# Altium schematic sheet Base_Circuit_REV04.SchDoc
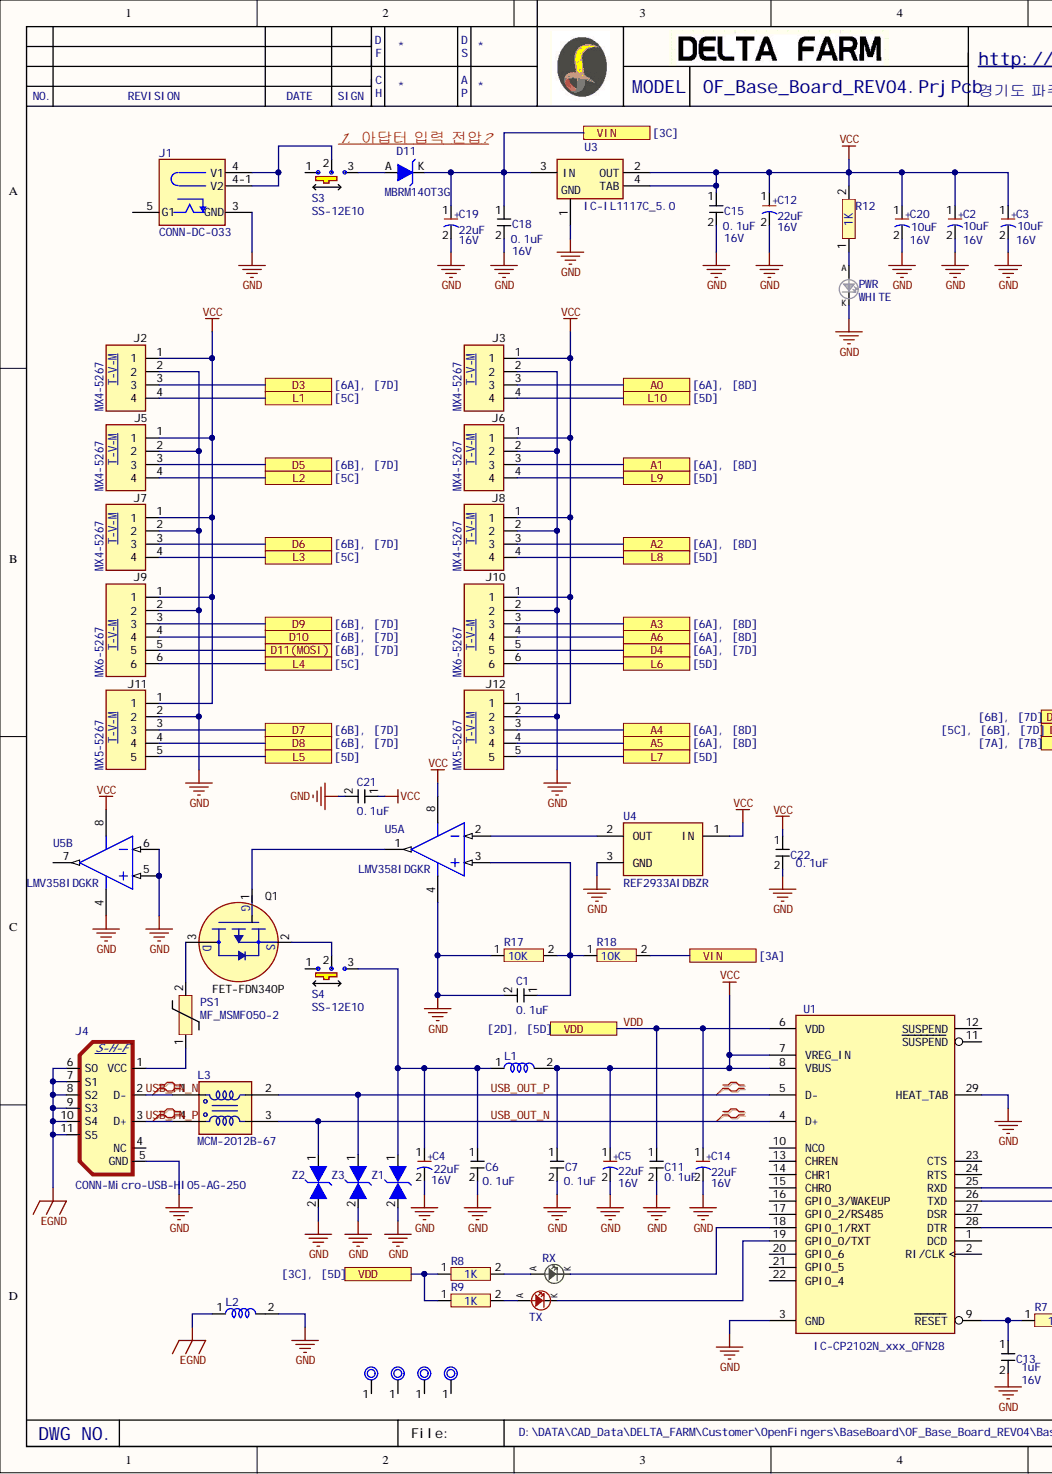
[diagram p0: Base_Circuit_REV04.SchDoc, left]
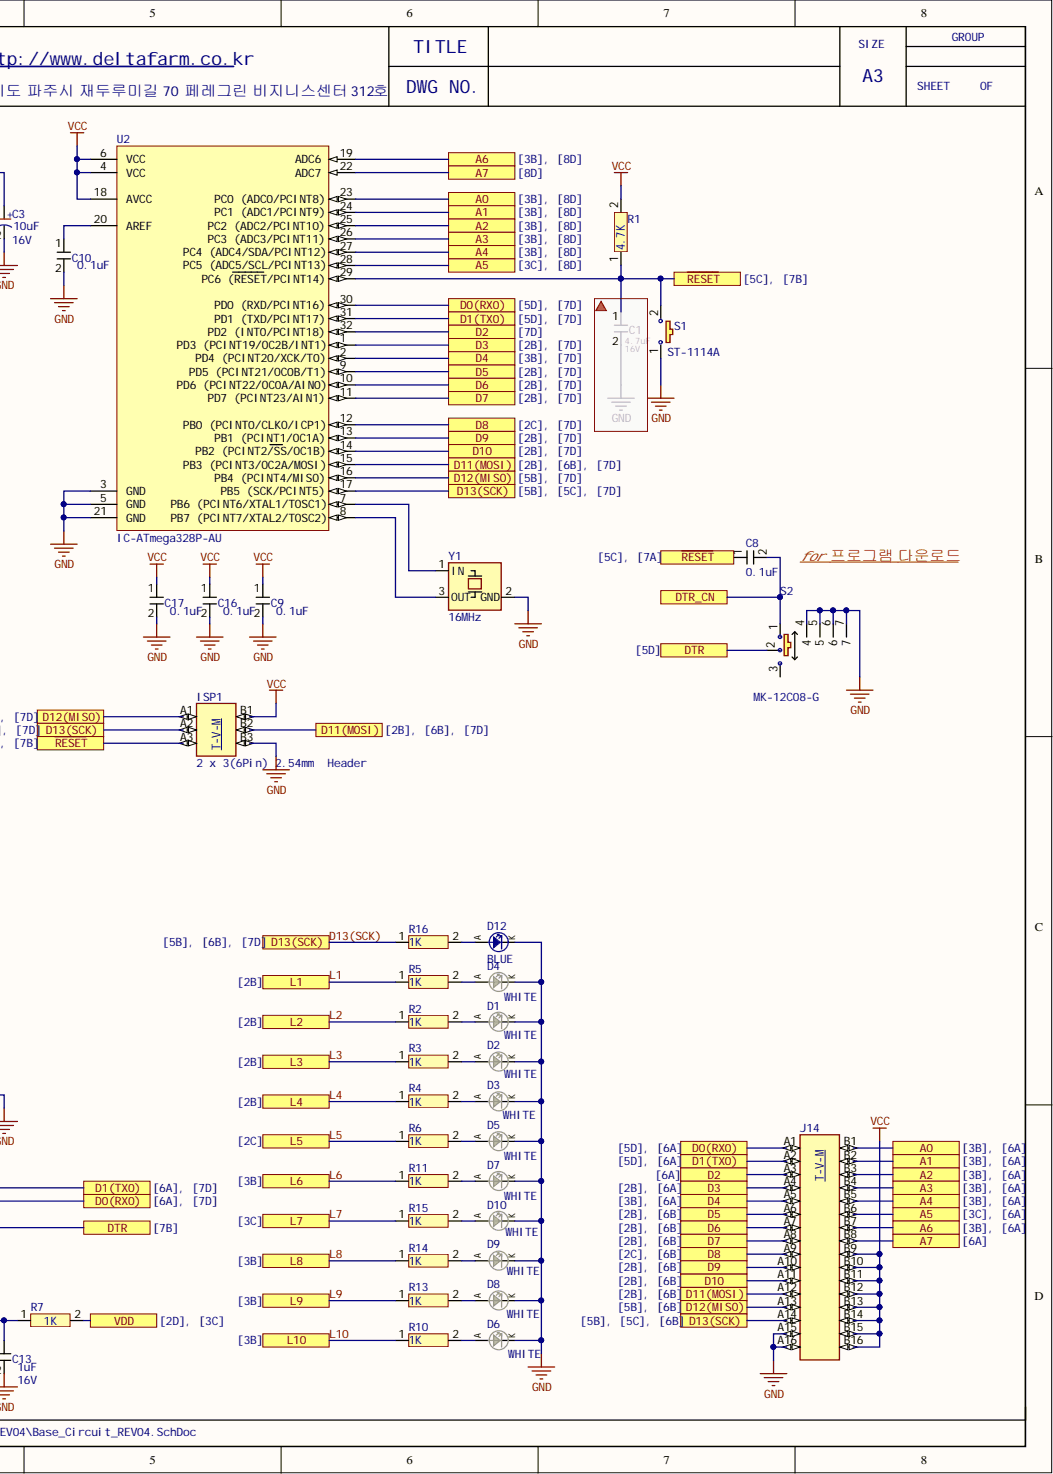
[diagram p1: Base_Circuit_REV04.SchDoc, right]

## Parts
C1  value 0.1uF  [CAP1608(EIA-0603)]  (hidden comment: CAP1608)
C2  value 10uF  VOLTAGE: 16V  [CAP-AE-B(D55)]  (hidden comment: CAP-AE-B(D55))
C3  value 10uF  VOLTAGE: 16V  [CAP-AE-B(D55)]  (hidden comment: CAP-AE-B(D55))
C4  value 22uF  VOLTAGE: 16V  [CAP-AE-C(E55)]  (hidden comment: CAP-AE-C(E55))
C5  value 22uF  VOLTAGE: 16V  [CAP-AE-C(E55)]  (hidden comment: CAP-AE-C(E55))
C6  value 0.1uF  [CAP1608(EIA-0603)]  (hidden comment: CAP1608)
C7  value 0.1uF  [CAP1608(EIA-0603)]  (hidden comment: CAP1608)
C8  value 0.1uF  [CAP1608(EIA-0603)]  (hidden comment: CAP1608)
C9  value 0.1uF  [CAP1608(EIA-0603)]  (hidden comment: CAP1608)
C10  value 0.1uF  [CAP1608(EIA-0603)]  (hidden comment: CAP1608)
C11  value 0.1uF  [CAP1608(EIA-0603)]  (hidden comment: CAP1608)
C12  value 22uF  VOLTAGE: 16V  [CAP-AE-C(E55)]  (hidden comment: CAP-AE-C(E55))
C13  value 1uF  VOLTAGE: 16V  [CAP1608(EIA-0603)]  (hidden comment: CAP1608)
C14  value 22uF  VOLTAGE: 16V  [CAP-AE-C(E55)]  (hidden comment: CAP-AE-C(E55))
C15  value 0.1uF  VOLTAGE: 16V  [CAP1608(EIA-0603)]  (hidden comment: CAP1608)
C16  value 0.1uF  [CAP1608(EIA-0603)]  (hidden comment: CAP1608)
C17  value 0.1uF  [CAP1608(EIA-0603)]  (hidden comment: CAP1608)
C18  value 0.1uF  VOLTAGE: 16V  [CAP1608(EIA-0603)]  (hidden comment: CAP1608)
C19  value 22uF  VOLTAGE: 16V  [CAP-AE-C(E55)]  (hidden comment: CAP-AE-C(E55))
C20  value 10uF  VOLTAGE: 16V  [CAP-AE-B(D55)]  (hidden comment: CAP-AE-B(D55))
C21  value 0.1uF  [CAP1608(EIA-0603)]  (hidden comment: CAP1608)
C22  value 0.1uF  [CAP1608(EIA-0603)]  (hidden comment: CAP1608)
D1  COLOR: WHITE  [LED3528(EIA-12108)_WHT]  (hidden comment: LED3528(EIA-12108)_WHT)
D2  COLOR: WHITE  [LED3528(EIA-12108)_WHT]  (hidden comment: LED3528(EIA-12108)_WHT)
D3  COLOR: WHITE  [LED3528(EIA-12108)_WHT]  (hidden comment: LED3528(EIA-12108)_WHT)
D4  COLOR: WHITE  [LED3528(EIA-12108)_WHT]  (hidden comment: LED3528(EIA-12108)_WHT)
D5  COLOR: WHITE  [LED3528(EIA-12108)_WHT]  (hidden comment: LED3528(EIA-12108)_WHT)
D6  COLOR: WHITE  [LED3528(EIA-12108)_WHT]  (hidden comment: LED3528(EIA-12108)_WHT)
D7  COLOR: WHITE  [LED3528(EIA-12108)_WHT]  (hidden comment: LED3528(EIA-12108)_WHT)
D8  COLOR: WHITE  [LED3528(EIA-12108)_WHT]  (hidden comment: LED3528(EIA-12108)_WHT)
D9  COLOR: WHITE  [LED3528(EIA-12108)_WHT]  (hidden comment: LED3528(EIA-12108)_WHT)
D10  COLOR: WHITE  [LED3528(EIA-12108)_WHT]  (hidden comment: LED3528(EIA-12108)_WHT)
D11  PART NO.: MBRM140T3G  [CASE457-04]  (hidden comment: DIODE-Schottky Power-MBRM140T3G)
D12  COLOR: BLUE  [LED1608(EIA-0603)-BLUE]  (hidden comment: LED1608(EIA-0603)_BLUE)
ISP1  2 x 3(6Pin) 2.54mm  Header  [CONN-SAMTEC_TSW-103-07-G-D]
J1  CONN-DC-033  [CONN-DC-JACK-DC033]
J2  PART NO: MX4-5267  [CONN-MX4-5267]  (hidden comment: CONN-MX4-5267)
J3  PART NO: MX4-5267  [CONN-MX4-5267]  (hidden comment: CONN-MX4-5267)
J4  CONN-Micro-USB-HI05-AG-250  [CONN-Micro-USB-HI05-AG-250]
J5  PART NO: MX4-5267  [CONN-MX4-5267]  (hidden comment: CONN-MX4-5267)
J6  PART NO: MX4-5267  [CONN-MX4-5267]  (hidden comment: CONN-MX4-5267)
J7  PART NO: MX4-5267  [CONN-MX4-5267]  (hidden comment: CONN-MX4-5267)
J8  PART NO: MX4-5267  [CONN-MX4-5267]  (hidden comment: CONN-MX4-5267)
J9  PART NO: MX6-5267  [CONN-MX6-5267]  (hidden comment: CONN-MX6-5267)
J10  PART NO: MX6-5267  [CONN-MX6-5267]  (hidden comment: CONN-MX6-5267)
J11  PART NO: MX5-5267  [CONN-MX5-5267]  (hidden comment: CONN-MX5-5267)
J12  PART NO: MX5-5267  [CONN-MX5-5267]  (hidden comment: CONN-MX5-5267)
J14  2 x 16(32Pin) 2.54mm Pitch Stacker  [CONN-SAMTEC_TSW-116-07-G-D]  (value not drawn)
L1  IND-FCM1608KF-221T05  [DUMMY_1608(EIA-0603)]  (value not drawn)
L2  IND-FCM1608KF-221T05  [DUMMY_1608(EIA-0603)]  (value not drawn)
L3  PART NO.: MCM-2012B-67  [IND-2012 (EIAJ2012 X 2)]  (hidden comment: Common_Mode Choke MCM 2012 B Series)
N1  DRIL_3.2_PAD_6.0  [MT_HOLE_3.2_6]  (value not drawn)
N2  DRIL_3.2_PAD_6.0  [MT_HOLE_3.2_6]  (value not drawn)
N3  DRIL_3.2_PAD_6.0  [MT_HOLE_3.2_6]  (value not drawn)
N4  DRIL_3.2_PAD_6.0  [MT_HOLE_3.2_6]  (value not drawn)
PS1  PART NO.: MF_MSMF050-2  [POLY4532(EIA-1812)]  (hidden comment: POLY_MF_MSMF050-2)
PWR  COLOR: WHITE  [LED3528(EIA-12108)_WHT]  (hidden comment: LED3528(EIA-12108)_WHT)
Q1  FET-FDN340P  [SOT23 -GSD]
R1  value 4.7K  [RES1608(EIA-0603)]  (hidden comment: RES1608(EIA-0603))
R2  value 1K  [RES1608(EIA-0603)]  (hidden comment: RES1608(EIA-0603))
R3  value 1K  [RES1608(EIA-0603)]  (hidden comment: RES1608(EIA-0603))
R4  value 1K  [RES1608(EIA-0603)]  (hidden comment: RES1608(EIA-0603))
R5  value 1K  [RES1608(EIA-0603)]  (hidden comment: RES1608(EIA-0603))
R6  value 1K  [RES1608(EIA-0603)]  (hidden comment: RES1608(EIA-0603))
R7  value 1K  [RES1608(EIA-0603)]  (hidden comment: RES1608(EIA-0603))
R8  value 1K  [RES1608(EIA-0603)]  (hidden comment: RES1608(EIA-0603))
R9  value 1K  [RES1608(EIA-0603)]  (hidden comment: RES1608(EIA-0603))
R10  value 1K  [RES1608(EIA-0603)]  (hidden comment: RES1608(EIA-0603))
R11  value 1K  [RES1608(EIA-0603)]  (hidden comment: RES1608(EIA-0603))
R12  value 1K  [RES1608(EIA-0603)]  (hidden comment: RES1608(EIA-0603))
R13  value 1K  [RES1608(EIA-0603)]  (hidden comment: RES1608(EIA-0603))
R14  value 1K  [RES1608(EIA-0603)]  (hidden comment: RES1608(EIA-0603))
R15  value 1K  [RES1608(EIA-0603)]  (hidden comment: RES1608(EIA-0603))
R16  value 1K  [RES1608(EIA-0603)]  (hidden comment: RES1608(EIA-0603))
R17  value 10K  [RES1608(EIA-0603)]  (hidden comment: RES1608(EIA-0603))
R18  value 10K  [RES1608(EIA-0603)]  (hidden comment: RES1608(EIA-0603))
RX  LED1608(EIA-0603)_GRN  [LED1608(EIA-0603)-GREEN]  (value not drawn)
S1  PART NO.: ST-1114A  [SW-TACT- ST-1114A]  (hidden comment: SW-TACT-ST-1114A)
S2  PART NO.: MK-12C08-G  [SW-Slide- MK12C08-G]  (hidden comment: SW-SLIDE-MK-12C08-G)
S3  PART NO.: SS-12E10  [SW-SLIDE-SS-12E10]  (hidden comment: SW-SLIDE-SS-12E10)
S4  PART NO.: SS-12E10  [SW-SLIDE-SS-12E10]  (hidden comment: SW-SLIDE-SS-12E10)
TX  LED1608(EIA-0603)_RED  [LED1608(EIA-0603)-RED]  (value not drawn)
U1  IC-CP2102N_xxx_QFN28  [QFN-28-5X5]
U2  IC-ATmega328P-AU  [TQFP-32-7X7]
U3  IC-IL1117C_5.0  [SOT-223]
U4  REF2933AIDBZR  [SOT23]
U5  LMV358IDGKR  [DGK0008A_L]  (parts A,B)
Y1  value 16MHz  [XTAL-CST  Series]  (hidden comment: CRYSTAL-CSTCE-XXX)
Z1  TVS-TEA10402V  [DUMMY_1005(EIA-0402)]  (value not drawn)
Z2  TVS-TEA10402V  [DUMMY_1005(EIA-0402)]  (value not drawn)
Z3  TVS-TEA10402V  [DUMMY_1005(EIA-0402)]  (value not drawn)

## Ports
A0, A1, A2, A3, A4, A5, A6, A7, D0(RX0), D1(TX0), D2, D3, D4, D5, D6, D7, D8, D9, D10, D11(MOSI), D12(MISO), D13(SCK), DTR, DTR_CN, L1, L2, L3, L4, L5, L6, L7, L8, L9, L10, VDD, VIN, ~{RESET}

## Nets
EGND: J4.6(S0) J4.7(S1) J4.8(S2) J4.9(S3) J4.10(S4) J4.11(S5) L2.1
GND: C1.2 C2.2 C3.2 C4.2 C5.2 C6.2 C7.2 C9.2 C10.2 C11.2 C12.2 C13.2 C14.2 C15.2 C16.2 C17.2 C18.2 C19.2 C20.2 C21.2 C22.2 D1.K D2.K D3.K D4.K D5.K D6.K D7.K D8.K D9.K D10.K D12.K ISP1.B3 J1.3(GND) J2.2 J3.2 J4.5(GND) J5.2 J6.2 J7.2 J8.2 J9.2 J10.2 J11.2 J12.2 J14.A15 J14.A16 L2.2 PWR.K R17.1 S1.1 S2.4 S2.5 S2.6 S2.7 U1.3(GND) U1.29(HEAT_TAB) U2.3(GND) U2.5(GND) U2.21(GND) U3.1 U4.3 U5.4 U5.5 U5.6 Y1.2 Z1.2 Z2.2 Z3.2
VCC: C2.1 C3.1 C5.1 C7.1 C9.1 C12.1 C15.1 C16.1 C17.1 C20.1 C21.1 C22.1 ISP1.B1 J2.1 J3.1 J5.1 J6.1 J7.1 J8.1 J9.1 J10.1 J11.1 J12.1 J14.B9 J14.B10 J14.B11 J14.B12 J14.B13 J14.B14 J14.B15 J14.B16 L1.2 R1.2 R12.2 U1.7(VREG_IN) U1.8(VBUS) U2.4(VCC) U2.6(VCC) U2.18(AVCC) U3.2(OUT) U3.4(TAB) U4.1 U5.8
A0: J3.3 J14.B1 U2.23((ADC0/PCINT8)) ; port A0
A1: J6.3 J14.B2 U2.24((ADC1/PCINT9)) ; port A1
A2: J8.3 J14.B3 U2.25((ADC2/PCINT10)) ; port A2
A3: J10.3 J14.B4 U2.26((ADC3/PCINT11)) ; port A3
A4: J12.3 J14.B5 U2.27((ADC4/SDA/PCINT12)) ; port A4
A5: J12.4 J14.B6 U2.28((ADC5/SCL/PCINT13)) ; port A5
A6: J10.4 J14.B7 U2.19(ADC6) ; port A6
A7: J14.B8 U2.22(ADC7) ; port A7
D0(RX0): J14.A1 U1.26(TXD) U2.30((RXD/PCINT16)) ; port D0(RX0)
D1(TX0): J14.A2 U1.25(RXD) U2.31((TXD/PCINT17)) ; port D1(TX0)
D2: J14.A3 U2.32((INT0/PCINT18)) ; port D2
D3: J2.3 J14.A4 U2.1((PCINT19/OC2B/INT1)) ; port D3
D4: J10.5 J14.A5 U2.2((PCINT20/XCK/T0)) ; port D4
D5: J5.3 J14.A6 U2.9((PCINT21/OC0B/T1)) ; port D5
D6: J7.3 J14.A7 U2.10((PCINT22/OC0A/AIN0)) ; port D6
D7: J11.3 J14.A8 U2.11((PCINT23/AIN1)) ; port D7
D8: J11.4 J14.A9 U2.12((PCINT0/CLKO/ICP1)) ; port D8
D9: J9.3 J14.A10 U2.13((PCINT1/OC1A)) ; port D9
D10: J9.4 J14.A11 U2.14((PCINT2/SS/OC1B)) ; port D10
D11(MOSI): ISP1.B2 J9.5 J14.A12 U2.15((PCINT3/OC2A/MOSI)) ; port D11(MOSI)
D12(MISO): ISP1.A1 J14.A13 U2.16((PCINT4/MISO)) ; port D12(MISO)
D13(SCK): ISP1.A2 J14.A14 R16.1 U2.17((SCK/PCINT5)) ; port D13(SCK)
DTR: S2.2 U1.28(DTR) ; port DTR
DTR_CN: C8.2 S2.1 ; port DTR_CN
L1: J2.4 R5.1 ; port L1
L2: J5.4 R2.1 ; port L2
L3: J7.4 R3.1 ; port L3
L4: J9.6 R4.1 ; port L4
L5: J11.5 R6.1 ; port L5
L6: J10.6 R11.1 ; port L6
L7: J12.5 R15.1 ; port L7
L8: J8.4 R14.1 ; port L8
L9: J6.4 R13.1 ; port L9
L10: J3.4 R10.1 ; port L10
~{RESET}: C8.1 ISP1.A3 R1.1 S1.2 U2.29((RESET/PCINT14)) ; port ~{RESET}
USB_IN_N: J4.2(D-) L3.1
USB_IN_P: J4.3(D+) L3.4
USB_OUT_N: L3.3 U1.4(D+) Z2.1
USB_OUT_P: L3.2 U1.5(D-) Z3.1
VDD: C11.1 C14.1 R7.2 R8.1 R9.1 U1.6(VDD) ; port VDD
VIN: C18.1 C19.1 D11.K R18.2 U3.3(IN) ; port VIN
NetC1_1: C1.1 R17.2 R18.1 U5.3
NetC4_1: C4.1 C6.1 L1.1 S4.3 Z1.1
NetC10_1: C10.1 U2.20(AREF)
NetC13_1: C13.1 R7.1 U1.9(RESET)
NetD1_A: D1.A R2.2
NetD2_A: D2.A R3.2
NetD3_A: D3.A R4.2
NetD4_A: D4.A R5.2
NetD5_A: D5.A R6.2
NetD6_A: D6.A R10.2
NetD7_A: D7.A R11.2
NetD8_A: D8.A R13.2
NetD9_A: D9.A R14.2
NetD10_A: D10.A R15.2
NetD11_A: D11.A S3.3
NetD12_A: D12.A R16.2
NetJ1_4: J1.4(V1) J1.4-1(V2) S3.2
NetJ4_1: J4.1(VCC) PS1.1
NetPS1_2: PS1.2 Q1.3
NetPWR_A: PWR.A R12.1
NetQ1_1: Q1.1 U5.1
NetQ1_2: Q1.2 S4.2
NetR8_2: R8.2 RX.A
NetR9_2: R9.2 TX.A
NetRX_K: RX.K U1.18(GPIO_1/RXT)
NetTX_K: TX.K U1.19(GPIO_0/TXT)
NetU2_7: U2.7((PCINT6/XTAL1/TOSC1)) Y1.1
NetU2_8: U2.8((PCINT7/XTAL2/TOSC2)) Y1.3
NetU4_2: U4.2 U5.2

Not connected: J1 5(G1); J4 4(NC); N1 1; N2 1; N3 1; N4 1; S2 3; S3 1; S4 1; U1 1(DCD) 2(RI/CLK) 10(NC0) 11(SUSPEND) 12(SUSPEND) 13(CHREN) 14(CHR1) 15(CHR0) 16(GPIO_3/WAKEUP) 17(GPIO_2/RS485) 20(GPIO_6) 21(GPIO_5) 22(GPIO_4) 23(CTS) 24(RTS) 27(DSR); U5 7

## Library data of the parts
C1 C6-C11 C16 C17 C22 = CAP1608(EIA-0603): CAP 1608 ,EIA 0603; VOLTAGE: 16V; Component Kind: Standard; ComponentLink1Description: Manufacturer URL; ComponentLink1URL: http://www.samtec.com; ComponentLink2Description: Datasheet; ComponentLink2URL: http://www.samtec.com/documents/webfiles/pdf/TSW_TH.PDF; ComponentLink3Description: Package Specification; ComponentLink3URL: http://www.samtec.com/documents/webfiles/cpdf/TSW-XXX-XX-XXX-X-XX-XXX-MKT.pdf; Library Name: DeltaFarm_Schlib.SchLib
C2 C3 C20 = CAP-AE-B(D55): Aluminum Electrolytic Capacitor, Size_B(D55); Component Kind: Standard; ComponentLink1Description: Manufacturer URL; ComponentLink1URL: http://www.samtec.com; ComponentLink2Description: Datasheet; ComponentLink2URL: http://www.samtec.com/documents/webfiles/pdf/TSW_TH.PDF; ComponentLink3Description: Package Specification; ComponentLink3URL: http://www.samtec.com/documents/webfiles/cpdf/TSW-XXX-XX-XXX-X-XX-XXX-MKT.pdf; Library Name: DeltaFarm_Schlib.SchLib
C4 C5 C12 C14 C19 = CAP-AE-C(E55): Aluminum Electrolytic Capacitor, Size_C(E55); Component Kind: Standard; ComponentLink1Description: Manufacturer URL; ComponentLink1URL: http://www.samtec.com; ComponentLink2Description: Datasheet; ComponentLink2URL: http://www.samtec.com/documents/webfiles/pdf/TSW_TH.PDF; ComponentLink3Description: Package Specification; ComponentLink3URL: http://www.samtec.com/documents/webfiles/cpdf/TSW-XXX-XX-XXX-X-XX-XXX-MKT.pdf; Library Name: DeltaFarm_Schlib.SchLib
C13 C15 C18 = CAP1608(EIA-0603): CAP 1608 ,EIA 0603; Component Kind: Standard; ComponentLink1Description: Manufacturer URL; ComponentLink1URL: http://www.samtec.com; ComponentLink2Description: Datasheet; ComponentLink2URL: http://www.samtec.com/documents/webfiles/pdf/TSW_TH.PDF; ComponentLink3Description: Package Specification; ComponentLink3URL: http://www.samtec.com/documents/webfiles/cpdf/TSW-XXX-XX-XXX-X-XX-XXX-MKT.pdf; Library Name: DeltaFarm_Schlib.SchLib
C21 = CAP1608(EIA-0603): CAP 1608 ,EIA 0603; VALUE: 0.1uF; VOLTAGE: 16V; Component Kind: Standard; ComponentLink1Description: Manufacturer URL; ComponentLink1URL: http://www.samtec.com; ComponentLink2Description: Datasheet; ComponentLink2URL: http://www.samtec.com/documents/webfiles/pdf/TSW_TH.PDF; ComponentLink3Description: Package Specification; ComponentLink3URL: http://www.samtec.com/documents/webfiles/cpdf/TSW-XXX-XX-XXX-X-XX-XXX-MKT.pdf; Library Name: DeltaFarm_Schlib.SchLib
D1-D10 PWR = LED3528(EIA-1210)_WHT: Component Kind: Standard; ComponentLink1Description: Manufacturer URL; ComponentLink1URL: http://www.samtec.com; ComponentLink2Description: Datasheet; ComponentLink2URL: http://www.samtec.com/documents/webfiles/pdf/TSW_TH.PDF; ComponentLink3Description: Package Specification; ComponentLink3URL: http://www.samtec.com/documents/webfiles/cpdf/TSW-XXX-XX-XXX-X-XX-XXX-MKT.pdf; Description: LED3528(EIA-12108)_WHT; PART NO.: LED3528(EIA-12108)_WHT; Library Name: DeltaFarm_Schlib.SchLib
D11 = DIODE-Schottky Power-MBRM140T3G: Diode, Surface Mount Schottky Power Rectifier; MANUFACTURER: ON-SEMI; Component Kind: Standard; ComponentLink1Description: Manufacturer URL; ComponentLink1URL: http://www.samtec.com; ComponentLink2Description: Datasheet; ComponentLink2URL: http://www.samtec.com/documents/webfiles/pdf/TSW_TH.PDF; ComponentLink3Description: Package Specification; ComponentLink3URL: http://www.samtec.com/documents/webfiles/cpdf/TSW-XXX-XX-XXX-X-XX-XXX-MKT.pdf; Library Name: DeltaFarm_Schlib.SchLib
ISP1 = CONN-SAMTEC-TSW-103-07-G-D: Through - HOLE Board Stacker , 2 x 3 , 2.54mm Pitch; MANUFACTURER: SAMTEC; Component Kind: Standard; ComponentLink1Description: Manufacturer URL; ComponentLink1URL: http://www.samtec.com; ComponentLink2Description: Datasheet; ComponentLink2URL: http://www.samtec.com/documents/webfiles/pdf/TSW_TH.PDF; ComponentLink3Description: Package Specification; ComponentLink3URL: http://www.samtec.com/documents/webfiles/cpdf/TSW-XXX-XX-XXX-X-XX-XXX-MKT.pdf; PART NO.: TSW-103-07-G-D; Library Name: DeltaFarm_Schlib.SchLib
J1 = CONN-DC-033: DC Power Jack, DC30V, 0.5A; Component Kind: Standard; PART NO: CONN-DC-033; PCB DECAL: CONN-DC-033; Library Name: DeltaFarm_Schlib.SchLib
J2 J3 J5-J8 = CONN-MX4-5267: Connector,Wafer- 4Pin Vertical Type; MANUFACTURER: MOLEX; Component Kind: Standard; PCB DECAL: MX4-5267; Library Name: DeltaFarm_Schlib.SchLib
J4 = CONN-Micro-USB-HI05-AG-250: Micro-USB Socket(B), Right Angle, SMT Type; MANUFACTURER: HyupJin J&K; Component Kind: Standard; ComponentLink1Description: Manufacturer URL; ComponentLink1URL: http://www.samtec.com; ComponentLink2Description: Datasheet; ComponentLink2URL: http://www.samtec.com/documents/webfiles/pdf/TSW_TH.PDF; ComponentLink3Description: Package Specification; ComponentLink3URL: http://www.samtec.com/documents/webfiles/cpdf/TSW-XXX-XX-XXX-X-XX-XXX-MKT.pdf; PART NO.: HI05-AG-250; Library Name: DeltaFarm_Schlib.SchLib
J9 J10 = CONN-MX6-5267: Connector,Wafer- 6Pin Vertical Type; MANUFACTURER: MOLEX; Component Kind: Standard; PCB DECAL: MX6-5267; Library Name: DeltaFarm_Schlib.SchLib
J11 J12 = CONN-MX5-5267: Connector,Wafer- 5Pin Vertical Type; MANUFACTURER: MOLEX; Component Kind: Standard; PCB DECAL: MX5-5267; Library Name: DeltaFarm_Schlib.SchLib
J14 = CONN-SAMTEC-TSW-116-07-G-D: Through - HOLE Board Stacker , 2 x 16 , 2.54mm Pitch, 10.92mm Height, 5.84mm(Stacker); MANUFACTURER: SAMTEC; Component Kind: Standard; ComponentLink1Description: Manufacturer URL; ComponentLink1URL: http://www.samtec.com; ComponentLink2Description: Datasheet; ComponentLink2URL: http://www.samtec.com/documents/webfiles/pdf/TSW_TH.PDF; ComponentLink3Description: Package Specification; ComponentLink3URL: http://www.samtec.com/documents/webfiles/cpdf/TSW-XXX-XX-XXX-X-XX-XXX-MKT.pdf; PART NO.: TSW-116-07-G-D; Library Name: DeltaFarm_Schlib.SchLib
L1 L2 = IND-FCM1608KF-221T05: Ferrite Baed ,  550mA, 220 Ohm; MANUFACTURER: TAI-TECH; Component Kind: Standard; ComponentLink1Description: Manufacturer URL; ComponentLink1URL: http://www.samtec.com; ComponentLink2Description: Datasheet; ComponentLink2URL: http://www.samtec.com/documents/webfiles/pdf/TSW_TH.PDF; ComponentLink3Description: Package Specification; ComponentLink3URL: http://www.samtec.com/documents/webfiles/cpdf/TSW-XXX-XX-XXX-X-XX-XXX-MKT.pdf; PART NO.: FCM1608KF-221T05; Library Name: DeltaFarm_Schlib.SchLib
L3 = Filter_Common_Mode_Choke-MCM2012: MANUFACTURER: INPAQ; Component Kind: Standard; ComponentLink1Description: Manufacturer URL; ComponentLink1URL: http://www.samtec.com; ComponentLink2Description: Datasheet; ComponentLink2URL: http://www.samtec.com/documents/webfiles/pdf/TSW_TH.PDF; ComponentLink3Description: Package Specification; ComponentLink3URL: http://www.samtec.com/documents/webfiles/cpdf/TSW-XXX-XX-XXX-X-XX-XXX-MKT.pdf; Description: Common_Mode Choke MCM 2012 B Series; Library Name: DeltaFarm_Schlib.SchLib
N1-N4 = MT_3.2_6: Mounting Hole , Drill 3.2 mm, PADS 6.0mm; Manufacturer: Samtec; PartNumber: TSW-102-26-G-S; Pitch: 2.54 mm; Component Kind: Standard; ComponentLink1Description: Manufacturer URL; ComponentLink1URL: http://www.samtec.com; ComponentLink2Description: Datasheet; ComponentLink2URL: http://www.samtec.com/documents/webfiles/pdf/TSW_TH.PDF; ComponentLink3Description: Package Specification; ComponentLink3URL: http://www.samtec.com/documents/webfiles/cpdf/TSW-XXX-XX-XXX-X-XX-XXX-MKT.pdf; DatasheetVersion: F-214; Mounting Technology: Through-hole; Orientation: Vertical; PackageDescription: .025[0.64] SQ. Post Terminal Strip Assembly; PackageReference: TSW-102-26-XX-S; PackageVersion: DM, 8/1988; RoHS: True; Temperature Range: -55 to 125 degC; Library Name: DeltaFarm_Schlib.SchLib
PS1 = POLY_MF_MSMF050-2: POLY SWITCH -microSMD010F , SIZE 3225(EIA-1210); MANUFACTURER: BOURNS; VALUE: 500mA/1000mA; Component Kind: Standard; ComponentLink1Description: Manufacturer URL; ComponentLink1URL: http://www.samtec.com; ComponentLink2Description: Datasheet; ComponentLink2URL: http://www.samtec.com/documents/webfiles/pdf/TSW_TH.PDF; ComponentLink3Description: Package Specification; ComponentLink3URL: http://www.samtec.com/documents/webfiles/cpdf/TSW-XXX-XX-XXX-X-XX-XXX-MKT.pdf; Library Name: DeltaFarm_Schlib.SchLib
Q1 = FET-FDN340P: P-CHANNEL,ENHANCEMENT MODE, PowerTrench MOS FET; MANUFACTURER: ONSEMI; PCB DECAL: SOT23; Component Kind: Standard; PART NO: FET-FDN340P; Library Name: DeltaFarm_Schlib.SchLib
R1-R18 = RES1608(EIA-0603): RES1608 (EIA-0603); Component Kind: Standard; ComponentLink1Description: Manufacturer URL; ComponentLink1URL: http://www.samtec.com; ComponentLink2Description: Datasheet; ComponentLink2URL: http://www.samtec.com/documents/webfiles/pdf/TSW_TH.PDF; ComponentLink3Description: Package Specification; ComponentLink3URL: http://www.samtec.com/documents/webfiles/cpdf/TSW-XXX-XX-XXX-X-XX-XXX-MKT.pdf; Library Name: DeltaFarm_Schlib.SchLib
S1 = SW-TACT-ST-1114A: SWITCH- TACT,HORIZOTAL, SMT; MANUFACTURER: 인성테크윈; Component Kind: Standard; ComponentLink1Description: Manufacturer URL; ComponentLink1URL: http://www.samtec.com; ComponentLink2Description: Datasheet; ComponentLink2URL: http://www.samtec.com/documents/webfiles/pdf/TSW_TH.PDF; ComponentLink3Description: Package Specification; ComponentLink3URL: http://www.samtec.com/documents/webfiles/cpdf/TSW-XXX-XX-XXX-X-XX-XXX-MKT.pdf; Library Name: DeltaFarm_Schlib.SchLib
S2 = SW-SLIDE-MK-12C08-G: SWITCH- SLIDE,VERTICAL, SMT; MANUFACTURER: DEALON; Component Kind: Standard; ComponentLink1Description: Manufacturer URL; ComponentLink1URL: http://www.samtec.com; ComponentLink2Description: Datasheet; ComponentLink2URL: http://www.samtec.com/documents/webfiles/pdf/TSW_TH.PDF; ComponentLink3Description: Package Specification; ComponentLink3URL: http://www.samtec.com/documents/webfiles/cpdf/TSW-XXX-XX-XXX-X-XX-XXX-MKT.pdf; Library Name: DeltaFarm_Schlib.SchLib
S3 S4 = SW-SLIDE-SS-12E10: SWITCH- SLIDE,VERTICAL, Through Hole, 3A,250V; MANUFACTURER: Google; Component Kind: Standard; ComponentLink1Description: Manufacturer URL; ComponentLink1URL: http://www.samtec.com; ComponentLink2Description: Datasheet; ComponentLink2URL: http://www.samtec.com/documents/webfiles/pdf/TSW_TH.PDF; ComponentLink3Description: Package Specification; ComponentLink3URL: http://www.samtec.com/documents/webfiles/cpdf/TSW-XXX-XX-XXX-X-XX-XXX-MKT.pdf; Library Name: DeltaFarm_Schlib.SchLib
U1 = IC-CP2102N_xxx_QFN28: IC,USBXpress™ Family; MANUFACTURER: SILICON LABS; PCB DECAL: QFN28; Component Kind: Standard; PART NO: CP2102N_xxx_QFN28; Library Name: DeltaFarm_Schlib.SchLib
U2 = IC-ATmega328P-AU: 8-bit AVR Microcontroller, 32KB Flash, 1KB EEPROM, 2KB SRAM, 32-pin TQFP, Industrial Grade (-40°C to 85°C); Code_JEDEC: MS-026-ABA; Component Kind: Standard; ComponentLink1Description: Manufacturer URL; ComponentLink1URL: http://www.atmel.com/; ComponentLink2Description: ATmega48A/48PA/88A/88PA/168A/168PA/328/328P Datasheet; ComponentLink2URL: http://www.atmel.com/dyn/resources/prod_documents/doc8271.pdf; ComponentLink3Description: ATmega48A/48PA/88A/88PA/168A/168PA/328/328P Summary; ComponentLink3URL: http://www.atmel.com/dyn/resources/prod_documents/8271S.pdf; DatasheetVersion: revD, May-2011; PackageDescription: 32-Lead, 7x7x1.0 mm Body, 0.8mm Lead Pitch, Thin Profile Plastic Quad Flat Package (TQFP); PackageReference: 32A; PackageVersion: revC, Oct-2010; PART NO: ATmega328P-AU; Library Name: DeltaFarm_Schlib.SchLib
U3 = IC-IL1117C_5.0: IC,1A, Low Noise,xxLow Dropout Positive Voltage Regulator; MANUFACTURER: IK Semicon; Component Kind: Standard; PART NO: IC-IL1117C_5.0; PCB DECAL: SOT-223; Library Name: DeltaFarm_Schlib.SchLib
U4 = IC-REF2933AIDBZR: 3.3 V, 100 ppm / degC, 50 uA in Series (Bandgap) Voltage Reference, -40 to 125 degC, 3-pin SOT-23 (DBZ), Green (RoHS & no Sb/Br); Manufacturer: Texas Instruments; Initial Accuracy @ 25/deg C(%): 2; Iq(Max)(uA): 50; Rating: Catalog; SubFamily: Series Voltage Reference; Temp Coeff(Max)(ppm/ degree C): 100; Temp Coeff(Typ)(ppm/ degree C): 35; VI(Max)(V): 3.5; VO(V): 3.3; Code_JEDEC: TO-236; Component Kind: Standard; ComponentLink1Description: Manufacturer URL; ComponentLink1URL: http://www.ti.com/; ComponentLink2Description: Datasheet; ComponentLink2URL: http://www.ti.com/general/docs/lit/getliterature.tsp?genericPartNumber=REF2933&fileType=pdf; ComponentLink3Description: Package Specification; ComponentLink3URL: http://www.ti.com/litv/pdf/mpds108a; DatasheetVersion: SBVS033B; Eco-Plan: Green (RoHS & no Sb/Br); Mounting Technology: Surface Mount; PackageDescription: 3-Pin Plastic Small Outline, Body 2.92 x 1.3 mm, Pitch 0.95 mm; PackageReference: DBZ0003A; PackageVersion: 4203227/B; PART NO: REF2933AIDBZR; PartNumber: REF2933AIDBZR; Library Name: DeltaFarm_Schlib.SchLib
U5 = IC-LMV358IDGKR: Low-Voltage Rail-to-Rail Output Operational Amplifier, 2.7 to 5.5 V, -40 to 125 degC, 8-Pin VSSOP (DGK), Green (RoHS & no Sb/Br), Tape and Reel; Manufacturer: Texas Instruments; Bandwidth: 1MHz; Common Mode Rejection Ratio: 50dB; Input Bias Current: 250nA; Input Offset Voltage (Vos): 7mV; Max Supply Voltage: 5.5V; Min Supply Voltage: 2.7V; Nominal Supply Current: 440uA; Number of Amplifiers: 2; Operating Supply Current: 210uA; Output Current: 160mA; Slew Rate: 1V/us; Supply Voltage: 5V; Voltage Gain: 100dB; Case/Package: MSOP; Component Kind: Standard; Contact Plating: Gold; Datasheet URL: http://www.ti.com/lit/ds/symlink/lmv358.pdf; Datasheet Version: SLOS263W, 10/2014; Gain Bandwidth Product: 1MHz; Height: 0.97mm; Lead Free: LeadFree; Length: 3mm; Manufacturer URL: http://www.ti.com/; Max Operating Temperature: 85degC; Min Operating Temperature: -40degC; Mount: SurfaceMount; Number of Channels: 2; Number of Circuits: 2; Number of Elements: 2; Number of Pins: 8; Output Current per Channel: 160mA; Package Description: 8-Pin Thin Small Outline Package, Body 3 x 3 mm, Pitch 0.65 mm; Package Quantity: 1; Package Reference: DGK0008A; Package URL: http://www.ti.com/litv/pdf/mpds028d; Packaging: TapeandReel; PART NO: LMV358IDGKR; Radiation Hardening: No; REACH SVHC: NoSVHC; RoHSCompliant: true; Unity Gain Bandwidth Product: 1MHz; Width: 3mm; Library Name: DeltaFarm_Schlib.SchLib
Y1 = CRYSTAL-CSTCE-XXX: MANUFACTURER: muRata; PART NO.: CSTCE16M; Component Kind: Standard; ComponentLink1Description: Manufacturer URL; ComponentLink1URL: http://www.samtec.com; ComponentLink2Description: Datasheet; ComponentLink2URL: http://www.samtec.com/documents/webfiles/pdf/TSW_TH.PDF; ComponentLink3Description: Package Specification; ComponentLink3URL: http://www.samtec.com/documents/webfiles/cpdf/TSW-XXX-XX-XXX-X-XX-XXX-MKT.pdf; Description: CRYSTAL-CSTCE-XXX; Library Name: DeltaFarm_Schlib.SchLib
Z1-Z3 = TVS-TEA10402V: TVS-TEA10402V, Transient Voltage Suppressors , 20V; MANUFACTURER: INPAQ; Component Kind: Standard; ComponentLink1Description: Manufacturer URL; ComponentLink1URL: http://www.samtec.com; ComponentLink2Description: Datasheet; ComponentLink2URL: http://www.samtec.com/documents/webfiles/pdf/TSW_TH.PDF; ComponentLink3Description: Package Specification; ComponentLink3URL: http://www.samtec.com/documents/webfiles/cpdf/TSW-XXX-XX-XXX-X-XX-XXX-MKT.pdf; PART NO.: TEA10402V; Library Name: DeltaFarm_Schlib.SchLib
D12 = LED1608(EIA-0603)_BLUE: Component Kind: Standard; ComponentLink1Description: Manufacturer URL; ComponentLink1URL: http://www.samtec.com; ComponentLink2Description: Datasheet; ComponentLink2URL: http://www.samtec.com/documents/webfiles/pdf/TSW_TH.PDF; ComponentLink3Description: Package Specification; ComponentLink3URL: http://www.samtec.com/documents/webfiles/cpdf/TSW-XXX-XX-XXX-X-XX-XXX-MKT.pdf; Description: LED1608(EIA-0603)_BLUE; Library Name: DeltaFarm_Schlib.SchLib
RX = LED1608(EIA-0603)_GRN: COLOR: GREEN; Component Kind: Standard; ComponentLink1Description: Manufacturer URL; ComponentLink1URL: http://www.samtec.com; ComponentLink2Description: Datasheet; ComponentLink2URL: http://www.samtec.com/documents/webfiles/pdf/TSW_TH.PDF; ComponentLink3Description: Package Specification; ComponentLink3URL: http://www.samtec.com/documents/webfiles/cpdf/TSW-XXX-XX-XXX-X-XX-XXX-MKT.pdf; Description: LED1608(EIA-0603)_GRN; Library Name: DeltaFarm_Schlib.SchLib
TX = LED1608(EIA-0603)_RED: Component Kind: Standard; ComponentLink1Description: Manufacturer URL; ComponentLink1URL: http://www.samtec.com; ComponentLink2Description: Datasheet; ComponentLink2URL: http://www.samtec.com/documents/webfiles/pdf/TSW_TH.PDF; ComponentLink3Description: Package Specification; ComponentLink3URL: http://www.samtec.com/documents/webfiles/cpdf/TSW-XXX-XX-XXX-X-XX-XXX-MKT.pdf; Description: LED1608(EIA-0603)_RED; Library Name: DeltaFarm_Schlib.SchLib
